# Altium schematic sheet clock.SchDoc
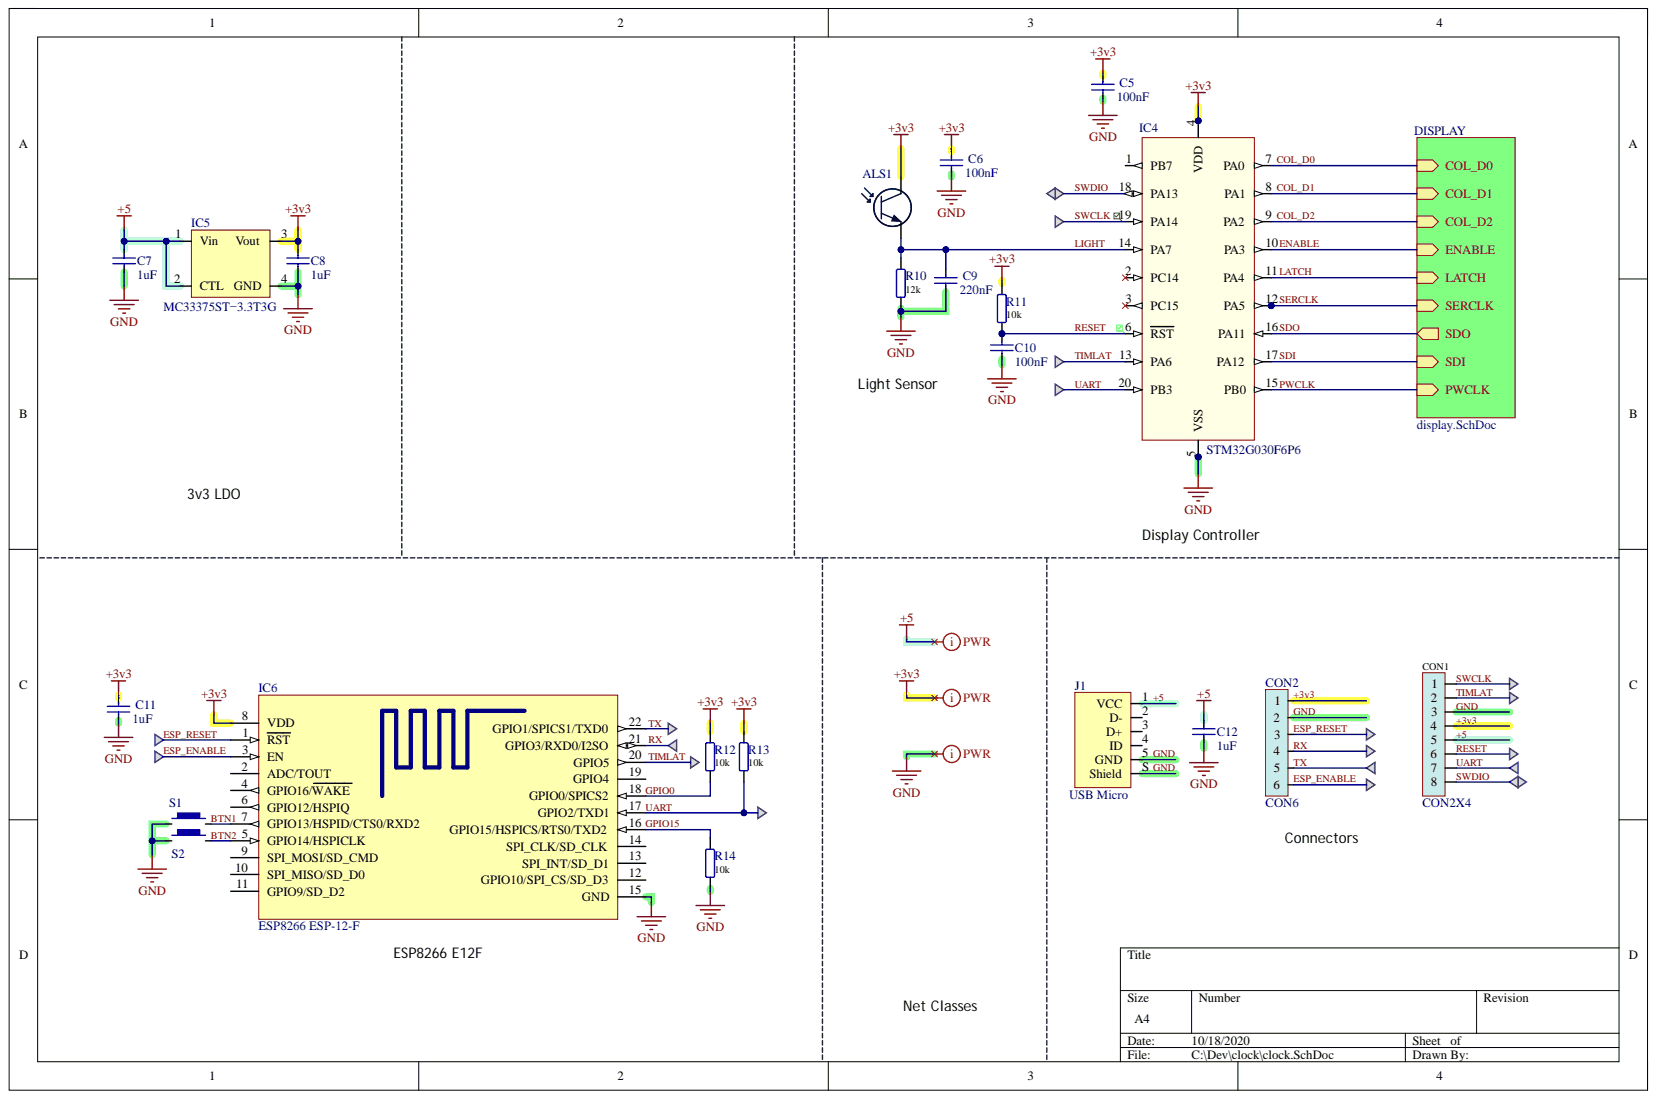
[diagram p0: clock.SchDoc]

## Parts
ALS1  PHOTOTRANSISTOR  [ALS-PT19-315C]  (value not drawn)
C5  value 100nF  [CAPC_0603_1608X08L]  (hidden comment: Cap)
C6  value 100nF  [CAPC_0603_1608X08L]  (hidden comment: Cap)
C7  value 1uF  [CAPC_0603_1608X08L]  (hidden comment: Cap)
C8  value 1uF  [CAPC_0603_1608X08L]  (hidden comment: Cap)
C9  value 220nF  [CAPC_0603_1608X08L]  (hidden comment: Cap)
C10  value 100nF  [CAPC_0603_1608X08L]  (hidden comment: Cap)
C11  value 1uF  [CAPC_0603_1608X08L]  (hidden comment: Cap)
C12  value 1uF  [CAPC_1206_3216X16L]  (hidden comment: Cap)
CON1  CON2X4  [CON2X4]  (no BOM)
CON2  CON6  [CON2X3]  (no BOM)
IC4  STM32G030F6P6  [TSOP65P630X120-20L]
IC5  MC33375ST−3.3T3G  [SOT230P700X180-4N]
IC6  ESP8266 ESP-12-F  [ESP8266 ESP-12-E]
J1  USB Micro  [USB 1051330011]
R10  value 12k  [RESC1608X03L]  (hidden comment: RES0603)
R11  value 10k  [RESC1608X03L]  (hidden comment: RES0603)
R12  value 10k  [RESC1608X03L]  (hidden comment: RES0603)
R13  value 10k  [RESC1608X03L]  (hidden comment: RES0603)
R14  value 10k  [RESC1608X03L]  (hidden comment: RES0603)
S1  4-1437565-1  [FSM-SWITCH]  (value not drawn)
S2  4-1437565-1  [FSM-SWITCH]  (value not drawn)

## Nets
+3v3: ALS1.1 C5.2 C6.2 C8.2 C11.2 CON1.4 CON2.1 IC4.4(VDD) IC5.3(Vout) IC6.8(VDD) R11.2 R12.1 R13.1
+5: C7.2 C12.2 CON1.5 IC5.1(Vin) IC5.2(CTL) J1.1(VCC)
BTN1: IC6.7(GPIO13/HSPID/CTS0/RXD2) S1.2
BTN2: IC6.5(GPIO14/HSPICLK) S2.2
COL_D0: IC4.7(PA0)
COL_D1: IC4.8(PA1)
COL_D2: IC4.9(PA2)
ENABLE: IC4.10(PA3)
ESP_ENABLE: CON2.6 IC6.3(EN)
ESP_RESET: CON2.3 IC6.1(RST)
GND: C5.1 C6.1 C7.1 C8.1 C9.1 C10.1 C11.1 C12.1 CON1.3 CON2.2 IC4.5(VSS) IC5.4(GND) IC6.15(GND) J1.5(GND) J1.S(Shield) R10.1 R14.2 S1.1 S2.1
GPIO0: IC6.18(GPIO0/SPICS2) R12.2
GPIO15: IC6.16(GPIO15/HSPICS/RTS0/TXD2) R14.1
LATCH: IC4.11(PA4)
LIGHT: ALS1.2 C9.2 IC4.14(PA7) R10.2
PWCLK: IC4.15(PB0)
RESET: C10.2 CON1.6 IC4.6(RST) R11.1
RX: CON2.4 IC6.21(GPIO3/RXD0/I2SO)
SDI: IC4.17(PA12)
SDO: IC4.16(PA11)
SERCLK: IC4.12(PA5)
SWCLK: CON1.1 IC4.19(PA14)
SWDIO: CON1.8 IC4.18(PA13)
TIMLAT: CON1.2 IC4.13(PA6) IC6.20(GPIO5)
TX: CON2.5 IC6.22(GPIO1/SPICS1/TXD0)
UART: CON1.7 IC4.20(PB3) IC6.17(GPIO2/TXD1) R13.2
NetIC4_1: IC4.1(PB7)
NetIC4_2: IC4.2(PC14)
NetIC4_3: IC4.3(PC15)
NetIC6_2: IC6.2(ADC/TOUT)
NetIC6_4: IC6.4(GPIO16/WAKE)
NetIC6_6: IC6.6(GPIO12/HSPIQ)
NetIC6_9: IC6.9(SPI_MOSI/SD_CMD)
NetIC6_10: IC6.10(SPI_MISO/SD_D0)
NetIC6_11: IC6.11(GPIO9/SD_D2)
NetIC6_12: IC6.12(GPIO10/SPI_CS/SD_D3)
NetIC6_13: IC6.13(SPI_INT/SD_D1)
NetIC6_14: IC6.14(SPI_CLK/SD_CLK)
NetIC6_19: IC6.19(GPIO4)
NetJ1_2: J1.2(D-)
NetJ1_3: J1.3(D+)
NetJ1_4: J1.4(ID)

## Library data of the parts
ALS1 = PHOTOTRANSISTOR: Photo transistor; Component Kind: Standard; Library Name: clock.SchLib
C5-C12 = Cap: Capacitor; Component Kind: Standard; LatestRevisionDate: 17-Jul-2002; LatestRevisionNote: Re-released for DXP Platform.; Library Name: clock.SchLib; PackageDescription: Radial Cap, Thru-Hole; 2 Leads; 0.3 in Pin Spacing; PackageReference: RAD-0.3; Published: 8-Jun-2000; Publisher: Altium Limited
CON1 = CON2X4: Header 4 way; Library Name: clock.SchLib
CON2 = CON6: Debug header 6 way; Library Name: clock.SchLib
IC4 = STM32G030F6P6: MCU 64MHz tssop20; Component Kind: Standard; Library Name: clock.SchLib
IC5 = MC33375ST−3.3T3G: 3.3v LDO; Component Kind: Standard; Library Name: clock.SchLib
J1 = USB 2.0 Type B Micro: USB 2.0 Type B Micro vertical SMT; ClassName: USB_CONNECTOR; Component Kind: Standard; Library Name: clock.SchLib
R10-R14 = RES0603: Chip Resistor; Component Kind: Standard; Library Name: clock.SchLib
S1 S2 = tactile switch: 6x6mm SMD tactile switch,SPST-NO; Manufacturer_Name: TE Connectivity; Allied_Number: R1117787; Component Kind: Standard; Datasheet Link: http://uk.rs-online.com/web/p/products/1616046; Library Name: clock.SchLib; Manufacturer_Part_Number: 4-1437565-1; RS Part Number: 1616046
IC6 = ESP8266 ESP-12-F: Component Kind: Standard; Description: ESP8266 ESP-12-F; Library Name: clock.SchLib
